# Altium schematic sheet Power.SchDoc
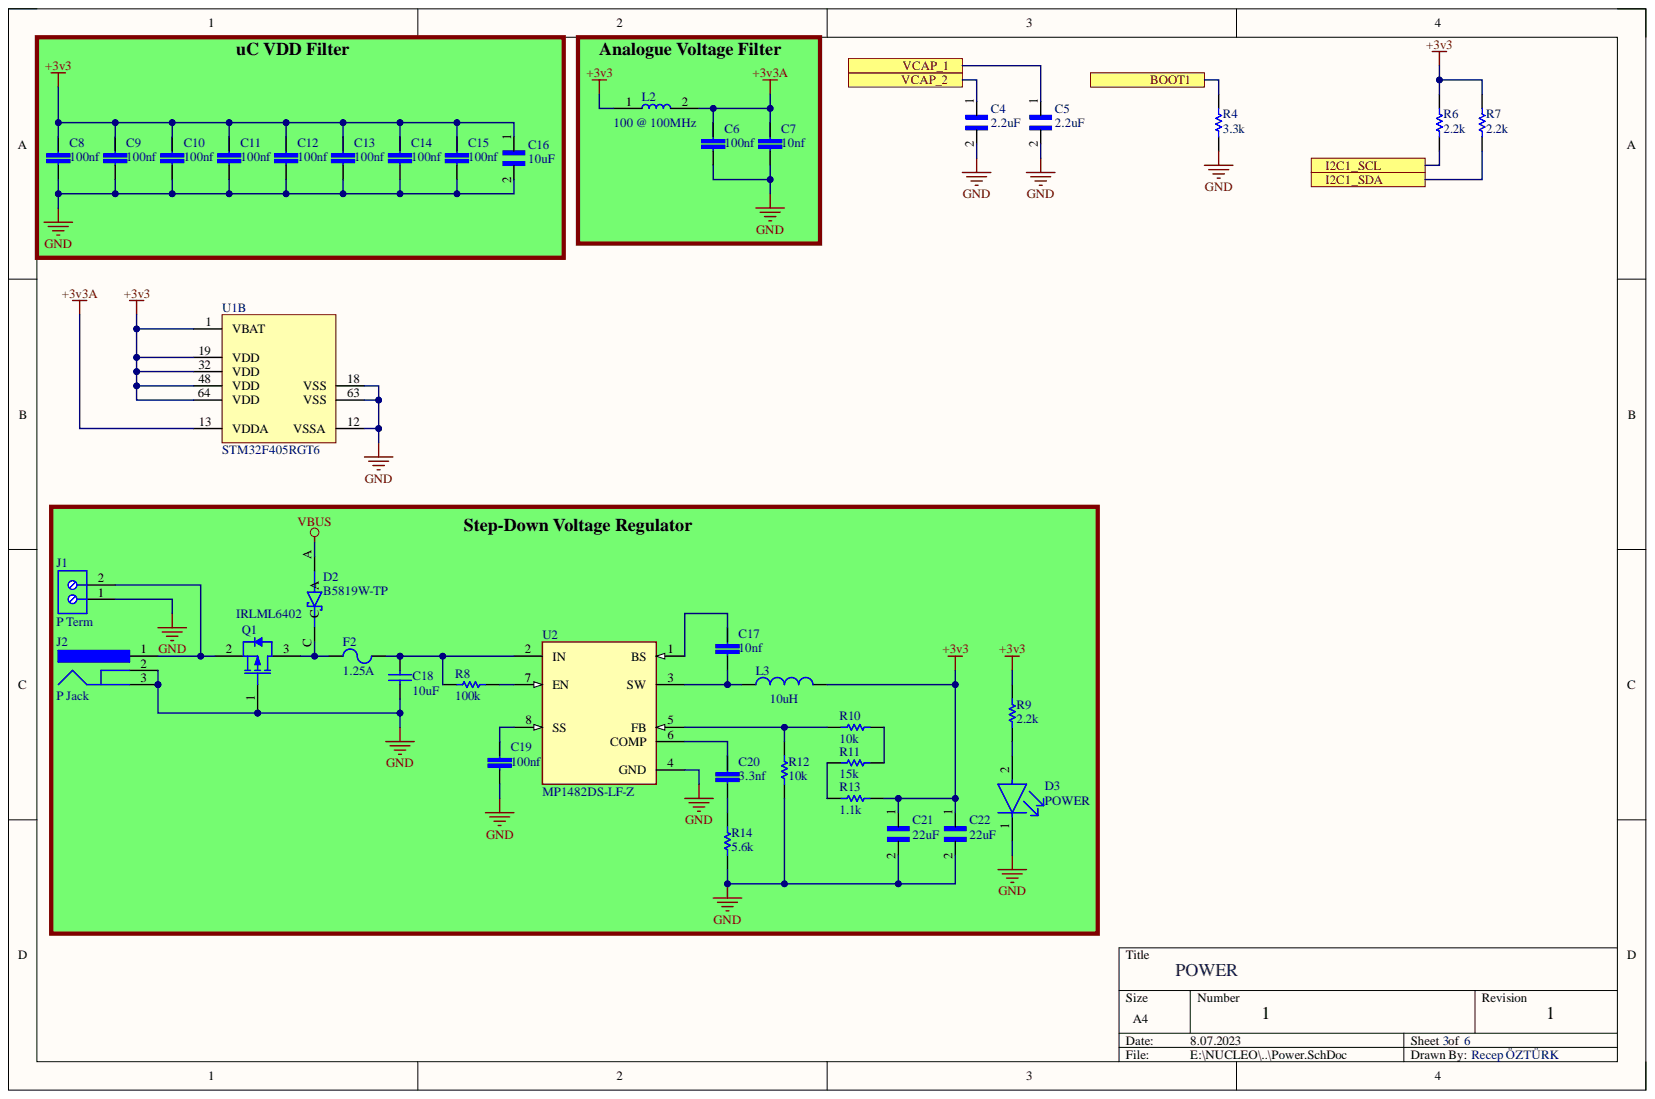
[diagram p0: Power.SchDoc]

## Parts
C4  2.2uF  [CAPC1608X95N]
C5  2.2uF  [CAPC1608X95N]
C6  100nf  [CAPC1005X55N]
C7  10nf  [CAPC1005X55N]
C8  100nf  [CAPC1005X55N]
C9  100nf  [CAPC1005X55N]
C10  100nf  [CAPC1005X55N]
C11  100nf  [CAPC1005X55N]
C12  100nf  [CAPC1005X55N]
C13  100nf  [CAPC1005X55N]
C14  100nf  [CAPC1005X55N]
C15  100nf  [CAPC1005X55N]
C16  10uF  [CAPC1608X95N]
C17  10nf  [CAPC1005X55N]
C18  10uF  [CAPC2013X95X45ML10T25]
C19  100nf  [CAPC1005X55N]
C20  3.3nf  [CAPC1005X55N]
C21  22uF  [CAPC1608X95N]
C22  22uF  [CAPC1608X95N]
D2  B5819W-TP  [SOD3716X135N]
D3  POWER  [FP-APT1608ZGCK-MFG]
F2  1.25A  [LFUS-2920L150DR_V]
J1  P Term  [TECO-282836-2_V]
J2  P Jack  [CUI-PJ-002A_V]
L2  100 @ 100MHz  [BEADC2012X105N]
L3  10uH  [FPI0504]
Q1  IRLML6402  [AO-SOT-23-3L_V]
R4  value 3.3k  [RESC1608X50N]  (hidden comment: Res1)
R6  value 2.2k  [RESC1608X50N]  (hidden comment: Res1)
R7  value 2.2k  [RESC1608X50N]  (hidden comment: Res1)
R8  value 100k  [RESC1608X50N]  (hidden comment: Res1)
R9  value 2.2k  [RESC1608X50N]  (hidden comment: Res1)
R10  value 10k  [RESC1608X50N]  (hidden comment: Res1)
R11  value 15k  [RESC1608X50N]  (hidden comment: Res1)
R12  value 10k  [RESC1608X50N]  (hidden comment: Res1)
R13  value 1.1k  [RESC1608X50N]  (hidden comment: Res1)
R14  5.6k  [RESC1005X40X25LL05T05]
U1  STM32F405RGT6  [STM-LQFP64_M]  (part B)
U2  MP1482DS-LF-Z  [FP-MP1482DS-LF-Z-MFG]

## Ports
BOOT1, I2C1_SCL, I2C1_SDA, VCAP_1, VCAP_2

## Nets
+3v3: C8.1 C9.1 C10.1 C11.1 C12.1 C13.1 C14.1 C15.1 C16.1 C21.1 C22.1 L2.1 L3.2 R6.2 R7.2 R9.2 R13.1 U1.1(VBAT) U1.19(VDD) U1.32(VDD) U1.48(VDD) U1.64(VDD)
+3v3A: C6.1 C7.1 L2.2 U1.13(VDDA)
GND: C4.2 C5.2 C6.2 C7.2 C8.2 C9.2 C10.2 C11.2 C12.2 C13.2 C14.2 C15.2 C16.2 C18.1 C19.2 C21.2 C22.2 D3.1 J1.1 J2.2 J2.3 Q1.1 R4.1 R12.1 R14.1 U1.12(VSSA) U1.18(VSS) U1.63(VSS) U2.4(GND)
VBUS: D2.A
BOOT1: R4.2 ; port BOOT1
I2C1_SCL: R6.1 ; port I2C1_SCL
I2C1_SDA: R7.1 ; port I2C1_SDA
VCAP_1: C5.1 ; port VCAP_1
VCAP_2: C4.1 ; port VCAP_2
NetC17_1: C17.1 U2.1(BS)
NetC17_2: C17.2 L3.1 U2.3(SW)
NetC18_2: C18.2 F2.2 R8.2 U2.2(IN)
NetC19_1: C19.1 U2.8(SS)
NetC20_1: C20.1 U2.6(COMP)
NetC20_2: C20.2 R14.2
NetD2_C: D2.C F2.1 Q1.3
NetD3_2: D3.2 R9.1
NetJ1_2: J1.2 J2.1 Q1.2
NetR8_1: R8.1 U2.7(EN)
NetR10_1: R10.1 R11.1
NetR10_2: R10.2 R12.2 U2.5(FB)
NetR11_2: R11.2 R13.2

## Library data of the parts
C4 C5 = CL21A106KAFN3NE: Multilayer Ceramic Capacitors MLCC - SMD/SMT 2.2uF 16V 0603 RoHS C23630; MF: {'manufacturer': u'Samsung Electro-Mechanics'}; Availability: Unavailable; Component Kind: Standard; Library Name: SnapEDA_Library.IntLib; MP: CL21A106KAFN3NE; Package: None; Price: None
C6 C8-C15 C19 = CL05B104KO5NFNC: 100nF 16v 0402  LCSC = C1525; MF: {'manufacturer': u'Samsung'}; Availability: Unavailable; Component Kind: Standard; Library Name: SnapEDA_Library.IntLib; MP: CL05B104KO5NFNC; Package: None; Price: None
C7 C17 = CL05B104KO5NFNC: 10nf 50v 0402  LCSC = C15195; MF: {'manufacturer': u'Samsung'}; Availability: Unavailable; Component Kind: Standard; Library Name: SnapEDA_Library.IntLib; MP: CL05B104KO5NFNC; Package: None; Price: None
C16 = CL21A106KAFN3NE: 10uF 10v 0603  LCSC = C19702; MF: {'manufacturer': u'Samsung Electro-Mechanics'}; Availability: Unavailable; Component Kind: Standard; Library Name: SnapEDA_Library.IntLib; MP: CL21A106KAFN3NE; Package: None; Price: None
C18 = CMP-2007-00182-1: Multilayer Ceramic Capacitors MLCC - SMD/SMT 10uF 25V 0805 RoHS   LCSC = C15850; Manufacturer: Murata; Part Number: GRM219R61E106KA12D; Component Kind: Standard; Library Name: Altium Content Vault
C20 = CL05B104KO5NFNC: 3.3nf 50v 0402  LCSC = C26404; MF: {'manufacturer': u'Samsung'}; Availability: Unavailable; Component Kind: Standard; Library Name: SnapEDA_Library.IntLib; MP: CL05B104KO5NFNC; Package: None; Price: None
C21 C22 = CL21A106KAFN3NE: Multilayer Ceramic Capacitors MLCC - SMD/SMT 22uF 6.3V 0603 RoHS   LCSC = C59461; MF: {'manufacturer': u'Samsung Electro-Mechanics'}; Availability: Unavailable; Component Kind: Standard; Library Name: SnapEDA_Library.IntLib; MP: CL21A106KAFN3NE; Package: None; Price: None
D2 = B5819W-TP: Diode Schottky 20v 1a Sod123  C8598; MF: {'manufacturer': u'Micro Commercial Components'}; Availability: Unavailable; Component Kind: Standard; Library Name: SnapEDA_Library.IntLib; MP: B5819W-TP; Package: None; Price: None
D3 = CMP-44529-000024-1: Light Emitting Diodes (LED) Green 520~535nm 112~258mcd@20mA Top View 0603 RoHS C72043; Dominant Wavelength: 525nm; Forward Current: 20mA; Forward Voltage: 3.3V; Lens Color: Colorless; Lens Transparency: Clear; Luminous Intensity: 350mcd; Viewing Angle: 120°; Case Code (Metric): 1608; Case Code (Imperial): 0603; Case/Package: 0603; Color: Green; Component Kind: Standard; Height: 0.75mm; Lead Free: Lead Free; Length: 1.6mm; Library Name: Altium Content Vault; Max Operating Temperature: 85°C; Min Operating Temperature: -40°C; Mount: Surface Mount; Package Quantity: 1; Packaging: Tape and Reel; Test Current: 20mA; Width: 0.8mm; Illumination Color: Green
F2 = CMP-2000-05549-1: Resettable PTC, 33 V,1.25A   Direnc.net FSMD125; Manufacturer: Littelfuse; Hold Current [Ih]: 1.5A; Trip Current: 3A; Working Voltage-Max: 33V; Component Kind: Standard; Datasheet URL: http://www.littelfuse.com/~/media/electronics/datasheets/resettable_ptcs/littelfuse_ptc_2920l_datasheet.pdf.pdf; Datasheet Version: 07/2016; Library Name: Altium Content Vault; Manufacturer URL: http://www.littelfuse.com/; Mounting Technology: SurfaceMount; Operating Temp Range: -40to+85°C; Package Description: 2-Pin Surface Mount Device, Body 5.12 x 7.355 mm; Package Reference: 2920L150DR; Size: 2920
J1 = CMP-1684-00017-1: Female Header, Pitch 5 mm, 1 x 2 Position, Height 10 mm, Tail Length 3.5 mm, Rohs, Bulk; Manufacturer: TE Connectivity; 3D Model: 282836-2.pdf; Clamp Material - Plating: Brass-NickelPlated; Current: 13.5A; Dynamic Catalog: BuchananSeries; Featured Product: EurostyleTerminalBlockConnectors; Mating Orientation: HorizontalwithBoard; Number of Levels: 1; Other Names: 5ULV-02B  A98076; Pitch: 0.197"(5.00mm); Positions Per Level: 2; Product Training Modules: Wire-to-BoardEurostyleTerminalBlocks; Screw Thread: M3; Torque - Screw: 0.68Nm(6Lb-In); Voltage: 300V; Wire Gauge: 16-30AWG; Wire Termination: Screw-RisingCageClamp; Category: Connectors,Interconnects; Color: Green; Component Kind: Standard; Contact Material - Plating: Brass-TinPlated; Datasheet URL: http://www.te.com.cn/commerce/DocumentDelivery/DDEController?Action=showdoc&DocId=Catalog+Section%7F1308389_EUROSTYLE_TERMINAL_BLOCKS%7F0607%7Fpdf%7FEnglish%7FENG_CS_1308389_EUROSTYLE_TERMINAL_BLOCKS_0607.pdf%7F282836-2; Family: TerminalBlocks-WiretoBoard; Features: Interlocking(Side); Housing Material: Polyamide(PA66),Nylon6/6; Library Name: Altium Content Vault; Manufacturer URL: http://www.te.com; Mounting Type: ThroughHole; Package Description: Female Header, Pitch 5 mm, 1 x 2 Position, Height 10 mm, Tail Length 3.5 mm; Package Reference: THD; Packaging: Bulk; RoHS Information: 282836-2StatementofCompliance; Screw Material - Plating: Brass-NickelPlated; Series: Buchanan; Standard Package: 300
J2 = CMP-2000-06895-1: Through Hole Right Angle DC Power Jack, 2.5 A, 2 mm Center Pin, 3 Position, -25 to 85 degC, RoHS, Bulk; Manufacturer: CUIInc.; Brand: CUI; Current Rating: 2.5A; Outside Contact Diameter: 6.5mm; Voltage Rating: 24V; Inside Contact Diameter: 2mm; Insulation Resistance: 50MOhms; Component Kind: Standard; Connector Type: DCPowerJack; Contact Gender: Male; Contact Material: Copper; Contact Plating: Nickel; Datasheet URL: http://www.cui.com/product/resource/pj-002a.pdf; Datasheet Version: Rev. 1.03, 04/2016; Factory Pack Quantity: 500; Flammability Rating: UL94V-0; Housing Material: PolybutyleneTerephthalate(PBT); Library Name: Altium Content Vault; Manufacturer URL: http://www.cui.com/; Mounting Angle: Right; Mounting Style: ThroughHole; Mounting Technology: ThroughHole; Operating Temperature Range: -25Cto+85C; Package Description: 3-Pin Through Hole Device, Body 14.4 x 9 mm, Height 11 mm; Package Reference: PJ-002A; Product: Jacks; Product Category: DCPowerConnectors; RoHS: True; Termination Style: ThroughHole
L2 = MMZ2012S601AT: 0805 SMD chip bead 100R 800mA 0.15DCR  LCSC = C1015; MF: {'manufacturer': u'TDK'}; Availability: Unavailable; Component Kind: Standard; Library Name: SnapEDA_Library.IntLib; MP: MMZ2012S601AT; Package: None; Price: None
L3 = Inductor: 10uH 5.2X5.8 2.3A  FPI0504; Code_IEC: 1005; Code_JEITA: 0402; Component Kind: Standard; LatestRevisionDate: 29-May-2009; LatestRevisionNote: IPC-7351 Footprint Added.; Library Name: Miscellaneous Devices.IntLib; PackageDescription: Chip Inductor; PackageReference: 0402-A; Published: 8-Jun-2000; Signal Integrity: Inductor; Simulation: INDUCTOR; Value: 10uH; Publisher: Altium Limited
Q1 = CMP-2000-05778-1: P-Channel MOSFET, 20v 3.7A; Manufacturer: Alpha and Omega; Channel Mode: Enhancement; Continuous Drain Current: 4A; Drain Efficiency: NotRequired%; Drain-Source On-Res: 0.044ohm; Drain-Source On-Volt: 30V; Frequency (Max): NotRequiredMHz; Gate-Source Voltage (Max): ?12V; Noise Figure: NotRequireddB; Number of  Elements: 1; Output Power (Max): NotRequiredW; Polarity: P; Power Dissipation: 1.4W; Rad Hardened: No; Type: PowerMOSFET; Component Kind: Standard; Datasheet URL: http://www.aosmd.com/res/data_sheets/AO3401A.pdf; Datasheet Version: Rev. 3, 03/2011; Drain Current (Max): 4A; Library Name: Altium Content Vault; Manufacturer URL: http://www.aosmd.com/; Mounting: SurfaceMount; Mounting Technology: SurfaceMount; Operating Temp Range: -55Cto150C; Operating Temperature Classification: Military; Package Description: 3-Pin Small Outline Transistor, Body 2.95 x 1.6 mm, Pitch 0.95 mm; Package Reference: SOT-23-3L; Package Type: SOT-23; Package URL: http://www.aosmd.com/res/packaging_information/SOT-23-3L.pdf; Pin Count: 3; Power Gain: NotRequireddB
R4 = Res1: Chip Resistor - Surface Mount 3.3KOhms ±1% 1/10W 0603 RoHS C22978; Component Kind: Standard; LatestRevisionDate: 17-Jul-2002; LatestRevisionNote: Re-released for DXP Platform.; Library Name: Miscellaneous Devices.IntLib; PackageDescription: Axial Device, Thru-Hole; 2 Leads; 0.3 in Pin Spacing; PackageReference: AXIAL-0.3; Published: 8-Jun-2000; Signal Integrity: Res; Simulation: RESISTOR; Publisher: Altium Limited
R6 R7 R9 = Res1: Chip Resistor - Surface Mount 2.2KOhms ±1% 1/10W 0603 RoHS C4190; Component Kind: Standard; LatestRevisionDate: 17-Jul-2002; LatestRevisionNote: Re-released for DXP Platform.; Library Name: Miscellaneous Devices.IntLib; PackageDescription: Axial Device, Thru-Hole; 2 Leads; 0.3 in Pin Spacing; PackageReference: AXIAL-0.3; Published: 8-Jun-2000; Signal Integrity: Res; Simulation: RESISTOR; Publisher: Altium Limited
R8 = Res1: CHIP RESISTOR - SURFACE MOUNT 100KOHMS ±1% 1/10W 0603 ROHS  LCSC = C25803; Component Kind: Standard; LatestRevisionDate: 17-Jul-2002; LatestRevisionNote: Re-released for DXP Platform.; Library Name: Miscellaneous Devices.IntLib; PackageDescription: Axial Device, Thru-Hole; 2 Leads; 0.3 in Pin Spacing; PackageReference: AXIAL-0.3; Published: 8-Jun-2000; Signal Integrity: Res; Simulation: RESISTOR; Publisher: Altium Limited
R10 R12 = Res1: CHIP RESISTOR - SURFACE MOUNT 10KOHMS ±1% 1/10W 0603 ROHS  LCSC = C25804; Component Kind: Standard; LatestRevisionDate: 17-Jul-2002; LatestRevisionNote: Re-released for DXP Platform.; Library Name: Miscellaneous Devices.IntLib; PackageDescription: Axial Device, Thru-Hole; 2 Leads; 0.3 in Pin Spacing; PackageReference: AXIAL-0.3; Published: 8-Jun-2000; Signal Integrity: Res; Simulation: RESISTOR; Publisher: Altium Limited
R11 = Res1: CHIP RESISTOR - SURFACE MOUNT 15KOHMS ±1% 1/10W 0603 ROHS  LCSC = C22809; Component Kind: Standard; LatestRevisionDate: 17-Jul-2002; LatestRevisionNote: Re-released for DXP Platform.; Library Name: Miscellaneous Devices.IntLib; PackageDescription: Axial Device, Thru-Hole; 2 Leads; 0.3 in Pin Spacing; PackageReference: AXIAL-0.3; Published: 8-Jun-2000; Signal Integrity: Res; Simulation: RESISTOR; Publisher: Altium Limited
R13 = Res1: CHIP RESISTOR - SURFACE MOUNT 1.1KOHMS ±1% 1/10W 0603 ROHS  LCSC = C22764; Component Kind: Standard; LatestRevisionDate: 17-Jul-2002; LatestRevisionNote: Re-released for DXP Platform.; Library Name: Miscellaneous Devices.IntLib; PackageDescription: Axial Device, Thru-Hole; 2 Leads; 0.3 in Pin Spacing; PackageReference: AXIAL-0.3; Published: 8-Jun-2000; Signal Integrity: Res; Simulation: RESISTOR; Publisher: Altium Limited
R14 = CMP-2002-00121-1: CHIP RESISTOR - SURFACE MOUNT 5.6KOHMS ±1% 1/16W 0402 ROHS  LCSC = C25908; Manufacturer: Vishay Dale; Part Number: CRCW04025K60FKED; Component Kind: Standard; Library Name: Altium Content Vault
U1 = CMP-0243-00019-3: ARM Cortex-M4 32-bit MCU+FPU, 210 DMIPS, 1024 kB Flash, 192 kB Internal RAM, 51 I/Os, 64-pin LQFP, -40 to 85 degC, Tray; Manufacturer: STMicroelectronics; D/A Converter: 2x12-bit; FLASH Size (Prog) (kB): 1024; Operating Frequency(F) (Processor speed) (MHz): 168; Supply Voltage(Vcc) max (V): 3.6; Supply Voltage(Vcc) min (V): 1.8; I/Os (High Current): 51; Internal RAM Size (kB): 192; Component Kind: Standard; ComponentLink1Description: Manufacturer URL; ComponentLink1URL: http://www.st.com/web/en/home.html; ComponentLink2Description: Datasheet; ComponentLink2URL: http://www.st.com/st-web-ui/static/active/en/resource/technical/document/datasheet/DM00037051.pdf; ComponentLink3Description: Package Specification; ComponentLink3URL: http://www.st.com/st-web-ui/static/active/en/resource/technical/document/datasheet/DM00037051.pdf; Core: ARM Cortex-M4; DatasheetVersion: Rev. 4; Library Name: Altium Content Vault; Mounting Technology: Surface Mount; PackageDescription: 64-Pin Low Profile Quad Flat Package, 10 x 10 mm Body, 0.5 mm Pitch; PackageReference: LQFP64; PackageVersion: Rev. 4, 06/2013; Packing: Tray; PartNumber: STM32F405RGT6
U2 = CMP-57379-000001-1: IC REG BUCK ADJUSTABLE 2A 8SOIC Diren.net; Manufacturer: Monolithic Power Systems; Max Duty Cycle: 90%; Max Junction Temp: +125°C; Max Power Dissipation: 1.38W; Max Supply Voltage: 18V; Min Supply Voltage: 4.75V; Nominal Supply Current: 1.3mA; Number Of Outputs: 1; Output Type: AdjustableProgrammable; Output Voltage: 0.923-15V; Standoff Height: 0.1mm; Switching Frequency: 340kHz; Ambient Temperature Range High: +85°C; Ambient Temperature Range Low: -40°C; Automotive: No; Category: IC; Component Kind: Standard; ComponentLink2Description: Datasheet; ComponentLink2URL: https://www.monolithicpower.com/en/documentview/productdocument/index/version/2/document_type/Datasheet/lang/en/sku/MP1482/document_id/344/; Device Class L1: Integrated Circuits (ICs); Device Class L2: Power Management ICs; Device Class L3: Voltage Regulators - Switching; Digikey Description: IC REG BUCK ADJUSTABLE 2A 8SOIC; Height: 1.75mm; Lead Free: Yes; Library Name: Altium Content Vault; Manufacturer Part Number: MP1482DS-LF-Z; Mouser Description: MP1482 Series 18 V 2 A SMT Synchronous Rectified Step-Down Converter-SOIC-8; Octopart: https://octopart.com/mp1482ds-lf-z-monolithic+power+systems-17392490; Output Current: 2A; Package: 0; RoHS: TRUE; Switching Topology: Buck; Temperature: -40°C to +125°C; Temperature Range High: +125°C; Temperature Range Low: -40°C; Ipc Land Pattern Name: SOIC127P600X155-8
